# Altium schematic sheet Sensor_Box_IO_Relay.SchDoc
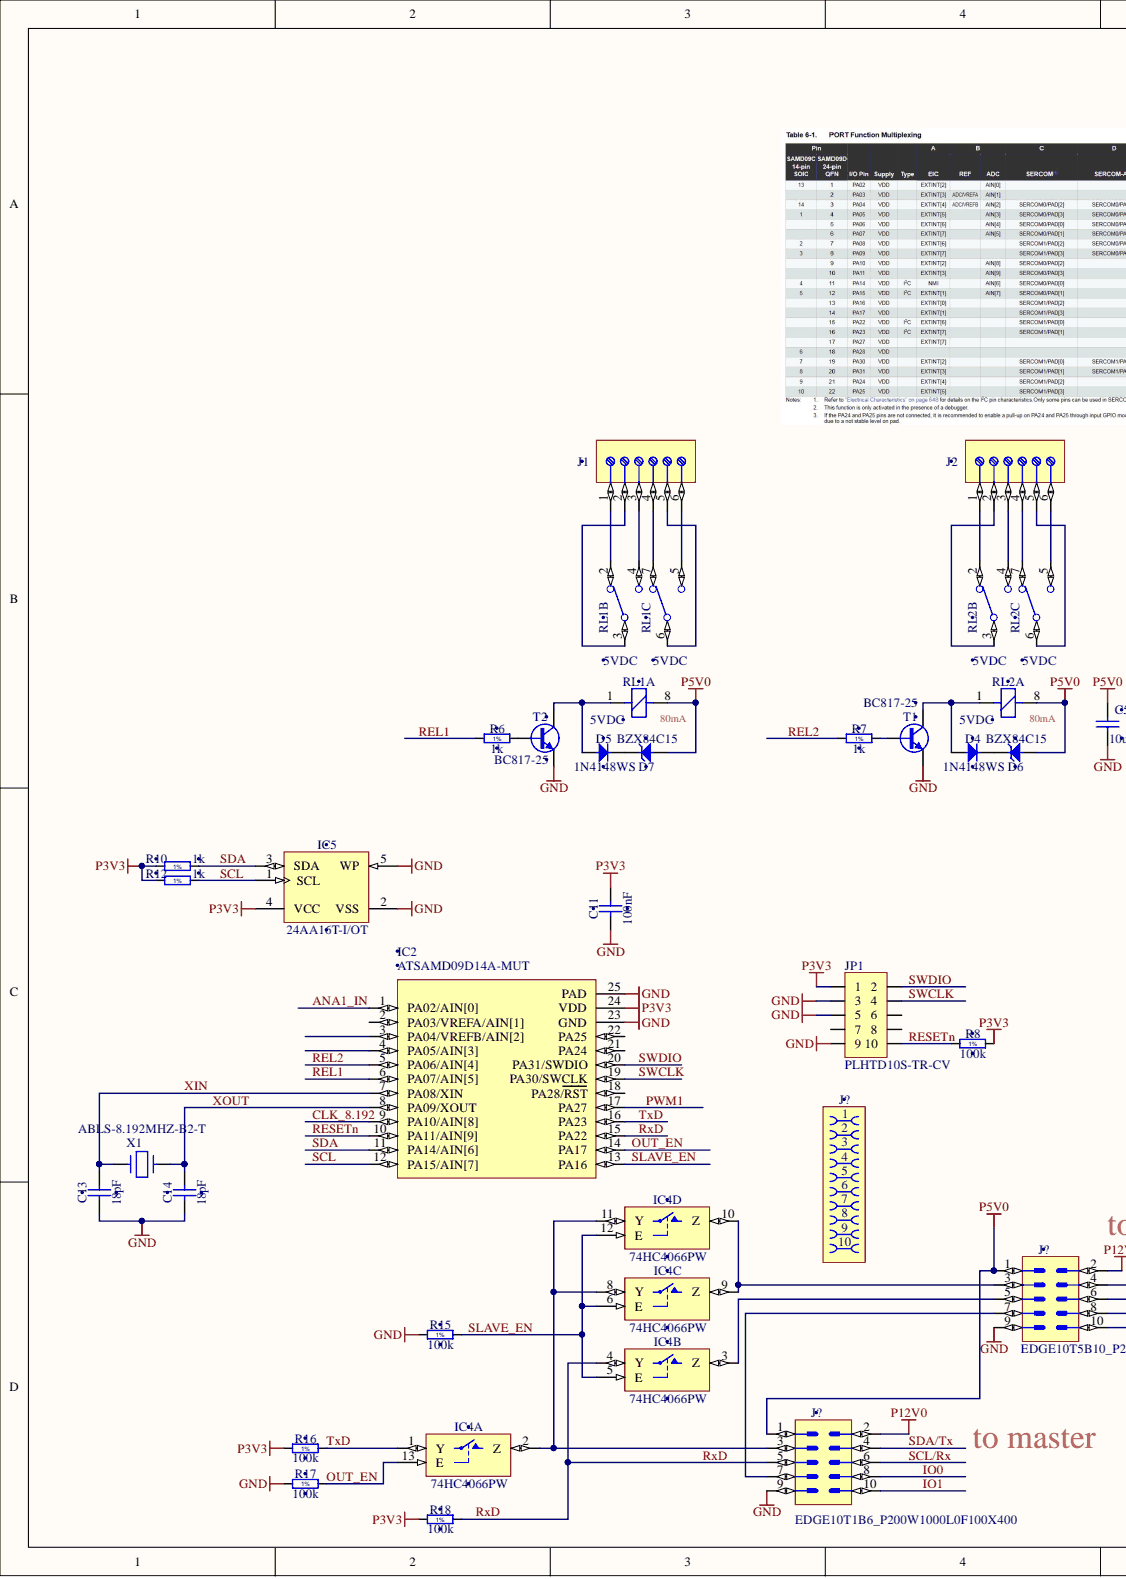
[diagram p0: Sensor_Box_IO_Relay.SchDoc, left]
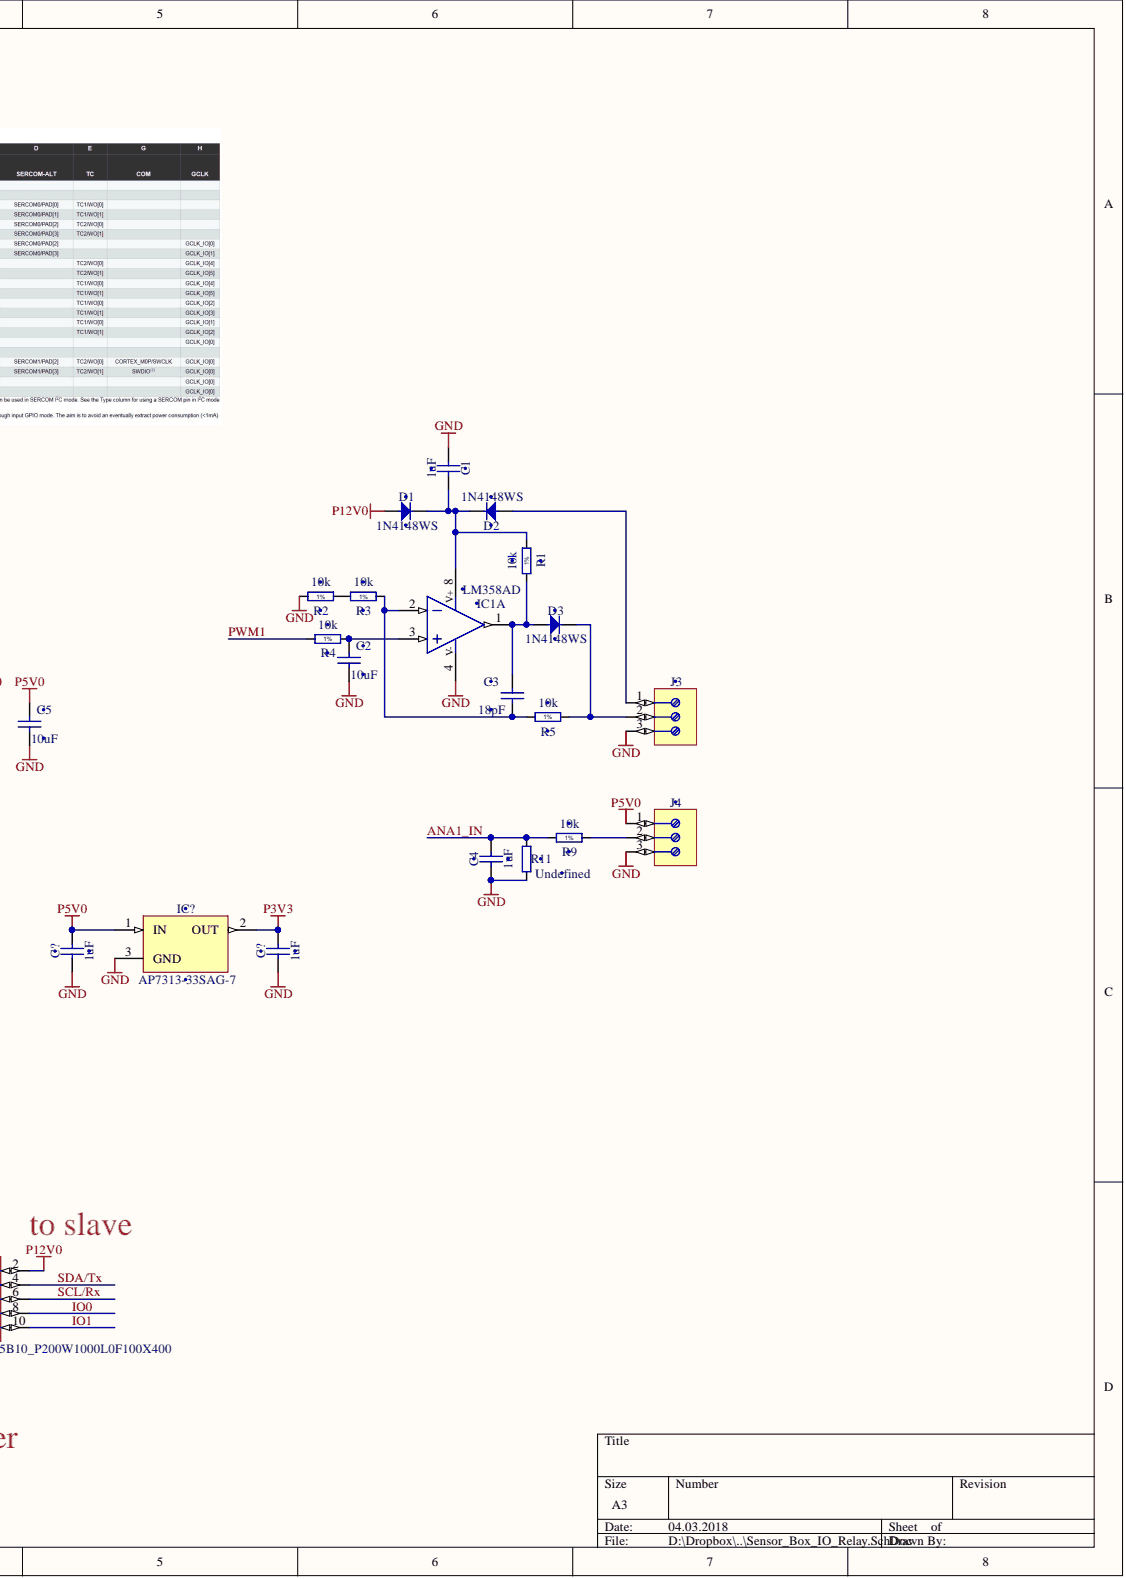
[diagram p1: Sensor_Box_IO_Relay.SchDoc, right]

## Parts
C1  1uF  [CAPC1005X55N]
C2  10uF  [CAPC2012X135N]
C3  18pF  [CAPC1005X55N]
C4  1uF  [CAPC1005X55N]
C5  10uF  [CAPC2012X135N]
C11  100nF  [CAPC1005X55N]
C13  18pF  [CAPC1005X55N]
C14  18pF  [CAPC1005X55N]
C?  1uF  [CAPC1608X87N]
C?  1uF  [CAPC1608X87N]
D1  1N4148WS  [SODFL2512X100N]
D2  1N4148WS  [SODFL2512X100N]
D3  1N4148WS  [SODFL2512X100N]
D4  1N4148WS  [SODFL2512X100N]
D5  1N4148WS  [SODFL2512X100N]
D6  BZX84C15  [SOT95P240X130-3N]
D7  BZX84C15  [SOT95P240X130-3N]
IC1  LM358AD  [SOIC127P600X175-8N]  (part A)
IC2  ATSAMD09D14A-MUT  [QFN50P400X400X80_HS-25N]
IC4  74HC4066PW  [SOP65P640X110-14N]  (parts A,B,C,D)
IC5  24AA16T-I/OT  [SOT95P280X145-5N]
IC?  AP7313-33SAG-7  [SOT95P285X140-3N]
J1  Family  [PHOENIX_1751138]  (value not drawn)
J2  Family  [PHOENIX_1751138]  (value not drawn)
J3  Family  [PHOENIX_1725669]  (value not drawn)
J4  Family  [PHOENIX_1725669]  (value not drawn)
J?  Family  [EDGE10T5B10_P200W1000L0F100X400]  (value not drawn)  (no BOM)
J?  Family  [EDGE10T1B6_P200W1000L0F100X400]  (value not drawn)  (no BOM)
J?
JP1  PLHTD10S-TR-CV  [PLHTD10S-TR-CV]
R1  10k  [RESC1005X40N]
R2  10k  [RESC1005X40N]
R3  10k  [RESC1005X40N]
R4  10k  [RESC1005X40N]
R5  10k  [RESC1005X40N]
R6  1k  [RESC1005X40N]
R7  1k  [RESC1005X40N]
R8  100k  [RESC1005X40N]
R9  10k  [RESC1005X40N]
R10  1k  [RESC1005X40N]
R11  Undefined  [RESC2012X60N]
R12  1k  [RESC1005X40N]
R15  100k  [RESC1005X40N]
R16  100k  [RESC1005X40N]
R17  100k  [RESC1005X40N]
R18  100k  [RESC1005X40N]
RL1  5VDC  [REL_OMRON_G2RL-2-5DC]  (parts A,B,C)
RL2  5VDC  [REL_OMRON_G2RL-2-5DC]  (parts A,B,C)
T1  BC817-25  [SOT95P230X110-3N]
T2  BC817-25  [SOT95P230X110-3N]
X1  ABLS-8.192MHZ-B2-T  [HC-49SM]

## Nets
GND: C1.1 C2.2 C4.1 C5.2 C11.1 C13.1 C14.1 C?.1 IC1.4 IC2.23(GND) IC2.25(PAD) IC4.7 IC5.2(VSS) IC5.5(WP) IC?.3 J3.3 J4.3 J?.9 JP1.3 JP1.5 JP1.9 R2.2 R11.1 R15.1 R17.1 T1.2 T2.2
P3V3: C11.2 C?.2 IC2.24(VDD) IC4.14 IC5.4(VCC) IC?.2 JP1.1 R8.2 R10.2 R12.2 R16.1 R18.1
P5V0: C5.1 C?.2 D6.1 D7.1 IC?.1 J4.1 J?.1 RL1.8 RL2.8
P12V0: D1.2 J?.2
ANA1_IN: C4.2 IC2.1(PA02/AIN[0]) R9.2 R11.2
CLK_8.192: IC2.9(PA10/AIN[8])
IO0: J?.8
IO1: J?.10
OUT_EN: IC2.14(PA17) IC4.13(E) R17.2
PWM1: IC2.17(PA27) R4.2
REL1: IC2.6(PA07/AIN[5]) R6.1
REL2: IC2.5(PA06/AIN[4]) R7.1
RESETn: IC2.10(PA11/AIN[9]) JP1.10 R8.1
RxD: IC2.15(PA22) IC4.4(Y) J?.5 R18.2
SCL: IC2.12(PA15/AIN[7]) IC5.1(SCL) R12.1
SCL/Rx: J?.6
SDA: IC2.11(PA14/AIN[6]) IC5.3(SDA) R10.1
SDA/Tx: J?.4
SLAVE_EN: IC2.13(PA16) IC4.5(E) IC4.6(E) IC4.12(E) R15.2
SWCLK: IC2.19(PA30/SWCLK) JP1.4
SWDIO: IC2.20(PA31/SWDIO) JP1.2
TxD: IC2.16(PA23) IC4.1(Y) R16.2
XIN: C13.2 IC2.7(PA08/XIN) X1.1
XOUT: C14.2 IC2.8(PA09/XOUT) X1.2
NetC1_2: C1.2 D1.1 D2.1 IC1.8 R1.1
NetC2_1: C2.1 IC1.3 R4.1
NetC3_1: C3.1 D3.2 IC1.1 R1.2
NetC3_2: C3.2 IC1.2 R3.1 R5.2
NetD2_2: D2.2 J3.1
NetD3_1: D3.1 J3.2 R5.1
NetD4_1: D4.1 D6.3
NetD4_2: D4.2 RL2.1 T1.3
NetD5_1: D5.1 D7.3
NetD5_2: D5.2 RL1.1 T2.3
NetIC4_2: IC4.2(Z) IC4.8(Y) IC4.11(Y) J?.3
NetIC4_3: IC4.3(Z) J?.5
NetIC4_9: IC4.9(Z) IC4.10(Z) J?.3
NetJ1_1: J1.1 RL1.2
NetJ1_2: J1.2 RL1.3
NetJ1_3: J1.3 RL1.4
NetJ1_4: J1.4 RL1.7
NetJ1_5: J1.5 RL1.6
NetJ1_6: J1.6 RL1.5
NetJ2_1: J2.1 RL2.2
NetJ2_2: J2.2 RL2.3
NetJ2_3: J2.3 RL2.4
NetJ2_4: J2.4 RL2.7
NetJ2_5: J2.5 RL2.6
NetJ2_6: J2.6 RL2.5
NetJ4_2: J4.2 R9.1
NetR2_1: R2.1 R3.2
NetR6_2: R6.2 T2.1
NetR7_2: R7.2 T1.1

Not connected: IC2 2(PA03/VREFA/AIN[1]) 3(PA04/VREFB/AIN[2]) 4(PA05/AIN[3]) 18(PA28/RST) 21(PA24) 22(PA25); J? 7; JP1 6 7 8

## Library data of the parts
C1 C4 = CC0402_1UF_16V_10%_X5R: Non-polarised capacitor; Manufacturer: GENERIC; Manufacturer1 Part Number: C1005X5R1C105KT; Part Description: SMD Multilayer Chip Ceramic Capacitor; Database Table Name: Capacitors SMD; TC: X5R; Tolerance: ±10%; Voltage: 16V; Case: 0402; Component Kind: Standard; Component Type: Standard; CreateDate: 25.10.2012; HelpURL: \\cern.ch\dfs\Applications\Altium\Datasheets\CC0402_X5R_TDK_C.pdf; LatestRevisionDate: 25.10.2012; Library Name: Capacitors.DbLib; Manufacturer1 ComponentHeight: 0.55mm; Manufacturer1 Example: TDK; Manufacturer Part Number: CC0402_1UF_16V_10%_X5R; Mounted: Yes; Part Number: CC0402_1UF_16V_10%_X5R; Pin Count: 2; Sense: No; SMD: Yes; Socket: No; Status: Preferred; Value: 1uF; Author: CERN DEM JLC
C2 C5 = CC0805_10UF_10V_10%_X7R: Non-polarised capacitor; Manufacturer: GENERIC; Manufacturer1 Part Number: GRM21BR71A106KE51L; Part Description: SMD Multilayer Chip Ceramic Capacitor; Database Table Name: Capacitors SMD; TC: X7R; Tolerance: ±10%; Voltage: 10V; Case: 0805; Component Kind: Standard; Component Type: Standard; CreateDate: 2012-04-30; HelpURL: \\cern.ch\dfs\Applications\Altium\Datasheets\CC0805_X7R_MURATA.pdf; LatestRevisionDate: 2012-04-30; Library Name: Capacitors.DbLib; Manufacturer1 ComponentHeight: 1.35mm; Manufacturer1 Example: MURATA; Manufacturer Part Number: CC0805_10UF_10V_10%_X7R; Mounted: Yes; Part Number: CC0805_10UF_10V_10%_X7R; Pin Count: 2; SMD: Yes; Socket: No; Status: Preferred; Table: Capacitors SMD; Value: 10uF; Author: CERN DEM JLC
C3 C13 C14 = CC0402_18PF_50V_5%_C0G: Non-polarised capacitor; Manufacturer: GENERIC; Manufacturer1 Part Number: C0402C180J5GACTU; Part Description: SMD Multilayer Chip Ceramic Capacitor; Database Table Name: Capacitors SMD; TC: C0G/NP0; Tolerance: ±5%; Voltage: 50V; Case: 0402; Component Kind: Standard; Component Type: Standard; CreateDate: 04.10.2016; HelpURL: \\cern.ch\dfs\Applications\Altium\Datasheets\CC0805_C0G_KEMET_(Commercial Grade).pdf; LatestRevisionDate: 04.10.2016; Library Name: Capacitors.DbLib; Manufacturer1 ComponentHeight: 0.55mm; Manufacturer1 Example: KEMET; Manufacturer Part Number: CC0402_18PF_50V_5%_C0G; Mounted: Yes; Part Number: CC0402_18PF_50V_5%_C0G; Pin Count: 2; Sense: No; SMD: Yes; Socket: No; Status: Preferred; Value: 18pF; Author: CERN DEM JLC
C11 = CC0402_100NF_16V_10%_X5R: Non-polarised capacitor; Manufacturer: GENERIC; Manufacturer1 Part Number: GRM155R61C104KA88D; Part Description: SMD Multilayer Chip Ceramic Capacitor; Database Table Name: Capacitors SMD; TC: X5R; Tolerance: ±10%; Voltage: 16V; Case: 0402; Component Kind: Standard; Component Type: Standard; CreateDate: 10.12.2013; HelpURL: \\cern.ch\dfs\Applications\Altium\Datasheets\CC0402_X5R_MURATA_GRM.pdf; LatestRevisionDate: 10.12.2013; Library Name: Capacitors.DbLib; Manufacturer1 ComponentHeight: 0.55mm; Manufacturer1 Example: MURATA; Manufacturer Part Number: CC0402_100NF_16V_10%_X5R; Mounted: Yes; Part Number: CC0402_100NF_16V_10%_X5R; Pin Count: 2; Sense: No; SMD: Yes; Socket: No; Status: None; Value: 100nF; Author: CERN DEM JLC
C? C? = CC0603_1UF_16V_10%_X7R: Non-polarised capacitor; Manufacturer: GENERIC; Manufacturer1 Part Number: 223878615663; Part Description: SMD Multilayer Chip Ceramic Capacitor; Database Table Name: Capacitors SMD; TC: X7R; Tolerance: ±10%; Voltage: 16V; Case: 0603; Component Kind: Standard; Component Type: Standard; CreateDate: 03.12.2007; HelpURL: \\cern.ch\dfs\Applications\Altium\Datasheets\CC0603_X7R_PHYCOMP.pdf; LatestRevisionDate: 17.04.2015; Library Name: Capacitors.DbLib; Manufacturer1 ComponentHeight: 0.9mm; Manufacturer1 Example: YAGEO PHYCOMP; Manufacturer Part Number: CC0603_1uF_16V_10%_X7R; Mounted: Yes; Part Number: CC0603_1UF_16V_10%_X7R; Pin Count: 2; Sense: No; SMD: Yes; Socket: No; Status: None; Value: 1uF; Author: CERN DEM JLC
D1-D5 = 1N4148WS: Diode; Manufacturer: FAIRCHILD SEMICONDUCTOR; Part Description: Small Signal Diodes; Bonding: No; Database Table Name: Diodes; Power: 150mA; PressFit: No; Voltage: 75V; Case: SOD-323F; ComponentHeight: 1mm; Component Kind: Standard; Component Type: Standard; CreateDate: 12.05.2011; Device: 1N4148WS; HelpURL: \\cern.ch\dfs\Applications\Altium\Datasheets\1N4148WS.pdf; LatestRevisionDate: 12.05.2011; Library Name: ICs And Semiconductors.DbLib; Manufacturer Part Number: 1N4148WS; Mounted: Yes; PackageDescription: SODFL Diode; 1.70mm W X 1.25mm L X 1.00mm H body, IPC Medium Density; Part Number: 1N4148WS; Pin Count: 2; Sense: No; SMD: Yes; Socket: No; Author: CERN DEM JLC
D6 D7 = BZX84C15: Diode Zener Anode Pin 1 Cathode Pin 3 (Pas de pin 2); Manufacturer: FAIRCHILD SEMICONDUCTOR; Part Description: Zener Diode; Bonding: No; Database Table Name: Diodes; Power: 350mW; PressFit: No; Voltage: 15V; Case: SOT23-3; ComponentHeight: 1.3mm; Component Kind: Standard; Component Type: Standard; CreateDate: 30.11.2011; Device: BZX84C15; HelpURL: \\cern.ch\dfs\Applications\Altium\Datasheets\BZX84C15.pdf; LatestRevisionDate: 30.11.2011; Library Name: ICs And Semiconductors.DbLib; Manufacturer Part Number: BZX84C15; Mounted: Yes; PackageDescription: SOT23 3-Leads, Pitch 0.95mm - Body 1.3x2.9mm, IPC Medium Density; Part Number: BZX84C15; Pin Count: 3; Sense: No; SMD: Yes; Socket: No; Author: CERN DEM JLC
IC1 = LM358AD: Dual Operational Amplifier; Manufacturer: TEXAS INSTRUMENTS; Bonding: No; Database Table Name: Operational Amplifiers; PressFit: No; Case: SOIC8; ComponentHeight: 1.75mm; Component Kind: Standard; Component Type: Standard; CreateDate: 02.12.2008; Device: LM358AD; HelpURL: \\cern.ch\dfs\Applications\Altium\Datasheets\LM358AD.pdf; LatestRevisionDate: 13.04.2010; Library Name: ICs And Semiconductors.DbLib; Manufacturer Part Number: LM358AD; Mounted: Yes; PackageDescription: SOIC 8, Pitch 1.27mm - Body 4x5mm, IPC Medium Density; Part Description: Dual Operational Amplifier; Part Number: LM358AD; Pin Count: 8; Sense: No; SMD: Yes; Socket: No; Author: CERN DEM JLC
IC2 = (no symbol name): 32-bit ARM®-based Cortex®-M4 core Microcontroller, 1.62V to 3.6V, 120MHz, 256KB Flash, 64KB of SRAM, 48 QFP, Industrial Grade (-40°C to 85°C); Manufacturer: Microchip; Part Description: ATSAMD09D14A-MUT  SMART SAMD09, SMART ARM based Microcontrollers, 32 bity, 48 MHz, 16 KB, 4 KB; Bonding: No; PressFit: No; Case: QFN24; ComponentHeight: 1.6mm; Component Kind: Standard; Component Type: Standard; CreateDate: 16.12.2017; Device: ATSAMD09D14A-MUT; HelpURL: \\cern.ch\dfs\Applications\Altium\Datasheets\ATSAM4S4AA-AU.pdf; LatestRevisionDate: 16.12.2017; Library Name: LIBRARY_SCH.SCHLIB; Manufacturer Part Number: ATSAMD09D14A-MUT; Mounted: Yes; PackageDescription: QFN, 24-Leads, Body 4.00x4.00mm, Pitch 0.50mm, Thermal Pad 2.60x2.60mm, IPC Medium Density; Part Number: ATSAMD09D14A-MUT; Pin Count: 24; Sense: No; SMD: Yes; Socket: No; Author: GK
IC4 = 74HC4066PW: Manufacturer: NXP SEMICONDUCTORS; Part Description: Quad Single-Pole Single-Throw Analog Switch; Bonding: No; Database Table Name: Standard Logic; PressFit: No; Case: TSSOP14; ComponentHeight: 1.1mm; Component Kind: Standard; Component Type: Standard; CreateDate: 19.11.2014; Device: 74HC4066PW; HelpURL: \\cern.ch\dfs\Applications\Altium\Datasheets\74HC4066PW.pdf; LatestRevisionDate: 19.11.2014; Library Name: ICs And Semiconductors.DbLib; Manufacturer Part Number: 74HC4066PW; Mounted: Yes; PackageDescription: SOP, 14-Leads, Body 4.4x5mm (max), Pitch 0.65mm, IPC Medium Density; Part Number: 74HC4066PW; Pin Count: 14; Sense: No; SMD: Yes; Socket: No; Author: CERN DEM JLC
IC5 = (no symbol name): 1.7V-5.5V, 2K I2C Serial EEPROM With EUI-48 Node Identity; Manufacturer: MICROCHIP TECHNOLOGY; Part Description: 1.7V-5.5V, 16K I2C Serial EEPROM; Bonding: No; PressFit: No; Case: SOT23-5; ComponentHeight: 1.45mm; Component Kind: Standard; Component Type: Standard; CreateDate: 31.03.2016; Device: 24AA16T-I/OT; HelpURL: \\cern.ch\dfs\Applications\Altium\Datasheets\24AA02E48T-I-OT.pdf; LatestRevisionDate: 31.03.2016; Library Name: LIBRARY_SCH.SCHLIB; Manufacturer Part Number: 24AA16T-I/OT; Mounted: Yes; PackageDescription: SOT, 0.95mm pitch; 5 pin, 1.60mm W X 2.90mm L X 1.45mm H body, IPC Medium Density; Part Number: 24AA16T-I/OT; Pin Count: 5; Sense: No; SMD: Yes; Socket: No; Author: GK
IC? = (no symbol name): 150mA, Low Quiescent Current, Fast Transient Low Dropout Linear Regulator; Manufacturer: DIODES INCORPORATED; Bonding: No; PressFit: No; Case: SOT23; ComponentHeight: 1.45mm; Component Kind: Standard; Component Type: Standard; CreateDate: 10.07.2015; Device: AP7313-33SAG-7; HelpURL: \\cern.ch\dfs\Applications\Altium\Datasheets\AP133-WG-7.pdf; LatestRevisionDate: 10.07.2015; Library Name: LIBRARY_SCH.SCHLIB; Manufacturer Part Number: AP7313-33SAG-7; Mounted: Yes; PackageDescription: SOT23, 0.95mm pitch; 3 pin, 1.6mm W X 3.0mm L X 1.45mm H body, IPC Medium Density; Part Description: 150mA, Low Quiescent Current, Fast Transient Low Dropout Linear Regulator; Part Number: AP7313-33SAG-7; Pin Count: 5; Sense: No; SMD: Yes; Socket: No; Author: GK
J1 J2 = PHOENIX_1751138: Terminal Block, 6 Positions, Screw Connection; Manufacturer: PHOENIX CONTACT; Manufacturer Part Number: 1751138 (SMKDS 1/ 6-3,5); Part Description: 10A 200V PCB Terminal Block, Pitch 3.5mm, 6 Positions, Screw Connection (Conductor/PCB connection direction: 55°); PressFit: No; ComponentHeight: 9.5mm; Component Kind: Standard; Component Type: Standard; CreateDate: 31.03.2015; Database Table Name: PHOENIX; HelpURL: \\cern.ch\dfs\Applications\Altium\Datasheets\PHOENIX_1751138.pdf; LatestRevisionDate: 31.03.2015; Library Name: Connectors.DbLib; Mounted: Yes; Part Number: PHOENIX_1751138; Pin Count: 6; Sense: No; SMD: No; Socket: No; Status: None; Author: CERN DEM JLC
J3 J4 = PHOENIX_1725669: Terminal Block, 3 Positions, Screw Connection; Manufacturer: PHOENIX CONTACT; Manufacturer Part Number: 1725669 (MPT 0,5/3-2,54); Part Description: 6A, 63V, Terminal Block, Pitch 2.54mm, 3 Positions, Screw Connection; PressFit: No; ComponentHeight: 8.5mm; Component Kind: Standard; Component Type: Standard; CreateDate: 07.11.2008; Database Table Name: PHOENIX; HelpURL: \\cern.ch\dfs\Applications\Altium\Datasheets\PHOENIX_1725669.pdf; LatestRevisionDate: 26.04.2010; Library Name: Connectors.DbLib; Mounted: Yes; Part Number: PHOENIX_1725669; Pin Count: 3; Sense: No; SMD: No; Socket: No; Status: None; Author: CERN DEM JMW
J? = EDGE10T5B10_P200W1000L0F100X400: PCB Edge Connector 10 (2x5); Part Description: 10 Contacts Board Edge Connector, Pitch 2mm, Length 0mm, Width 10mm, Finger Lenght 4mm, Finger Width 1mm; Database Table Name: Miscellaneous; Mounted: No; PressFit: No; ComponentHeight: 0mm; Component Type: Standard (No BOM); CreateDate: 14.02.2018; HelpURL: \\cern.ch\dfs\Applications\Altium\Datasheets\EDGE10T5B10_P200W1000L0F100X400.pdf; LatestRevisionDate: 14.02.2018; Library Name: Connectors.DbLib; Part Number: EDGE10T5B10_P200W1000L0F100X400; Pin Count: 10; Sense: No; SMD: No; Socket: No; Status: None; Author: CERN DEM MR
J? = EDGE10T1B6_P200W1000L0F100X400: PCB Edge Connector 10 (2x5); Part Description: 10 Contacts Board Edge Connector, Pitch 2mm, Length 0mm, Width 10mm, Finger Lenght 4mm, Finger Width 1mm; Database Table Name: Miscellaneous; Mounted: No; PressFit: No; ComponentHeight: 0mm; Component Type: Standard (No BOM); CreateDate: 14.02.2018; HelpURL: \\cern.ch\dfs\Applications\Altium\Datasheets\EDGE10T1B6_P200W1000L0F100X400.pdf; LatestRevisionDate: 14.02.2018; Library Name: Connectors.DbLib; Part Number: EDGE10T1B6_P200W1000L0F100X400; Pin Count: 10; Sense: No; SMD: No; Socket: No; Status: None; Author: CERN DEM MR
JP1 = CON_2x5 1.27 SMT Male: 1.27 mm dual row goldpin (Maritex); Component Kind: Standard; Library Name: LIBRARY_SCH.SCHLIB
R1-R5 R9 = R0402_10K_1%_0.0625W_100PPM: Resistor - 1%; Manufacturer: GENERIC; Manufacturer1 Part Number: 232270671003L; Part Description: General Purpose Thick Film Chip Resistor; Database Table Name: Resistors SMD; TC: ±100ppm/°C; Case: 0402; Component Kind: Standard; Component Type: Standard; CreateDate: 2007-12-03; HelpURL: \\cern.ch\dfs\Applications\Altium\Datasheets\R0402_Phycomp_RC0402.pdf; LatestRevisionDate: 2012-10-17; Library Name: Resistors.DbLib; Manufacturer1 ComponentHeight: 0.4mm; Manufacturer1 Example: YAGEO PHYCOMP; Manufacturer Part Number: R0402_10K_1%_0.0625W_100PPM; Mounted: Yes; Part Number: R0402_10K_1%_0.0625W_100PPM; Pin Count: 2; Power: 0.0625W; SMD: Yes; Socket: No; Status: Not recommended; Table: Resistors SMD; Tolerance: ±1%; Value: 10k; Author: CERN DEM JLC
R6 R7 R10 R12 = R0402_1K_1%_0.0625W_100PPM: Resistor - 1%; Manufacturer: GENERIC; Manufacturer1 Part Number: 232270671002L; Part Description: General Purpose Thick Film Chip Resistor; Database Table Name: Resistors SMD; PressFit: No; TC: ±100ppm/°C; Case: 0402; Component Kind: Standard; Component Type: Standard; CreateDate: 03.12.2007; HelpURL: \\cern.ch\dfs\Applications\Altium\Datasheets\R0402_Phycomp_RC0402.pdf; LatestRevisionDate: 17.10.2012; Library Name: Resistors.DbLib; Manufacturer1 ComponentHeight: 0.4mm; Manufacturer1 Example: YAGEO PHYCOMP; Manufacturer Part Number: R0402_1K_1%_0.0625W_100PPM; Mounted: Yes; Part Number: R0402_1K_1%_0.0625W_100PPM; Pin Count: 2; Power: 0.0625W; Sense: No; SMD: Yes; Socket: No; Status: Not Recommended; Tolerance: ±1%; Value: 1k; Author: CERN DEM JLC
R8 R15-R18 = R0402_100K_1%_0.0625W_100PPM: Resistor - 1%; Manufacturer: GENERIC; Manufacturer1 Part Number: 232270671004L; Part Description: General Purpose Thick Film Chip Resistor; Database Table Name: Resistors SMD; PressFit: No; TC: ±100ppm/°C; Case: 0402; Component Kind: Standard; Component Type: Standard; CreateDate: 03.12.2007; HelpURL: \\cern.ch\dfs\Applications\Altium\Datasheets\R0402_Phycomp_RC0402.pdf; LatestRevisionDate: 17.10.2012; Library Name: Resistors.DbLib; Manufacturer1 ComponentHeight: 0.4mm; Manufacturer1 Example: YAGEO PHYCOMP; Manufacturer Part Number: R0402_100K_1%_0.0625W_100PPM; Mounted: Yes; Part Number: R0402_100K_1%_0.0625W_100PPM; Pin Count: 2; Power: 0.0625W; Sense: No; SMD: Yes; Socket: No; Status: Not Recommended; Tolerance: ±1%; Value: 100k; Author: CERN DEM JLC
R11 = R0805_NO-VALUE: Resistor; Part Description: Reserved Footprint For a 0805 (2012) Chip Resistor; Database Table Name: Resistors SMD; Mounted: No; PressFit: No; Case: 0805; Component Kind: Standard; Component Type: Standard; CreateDate: 03.12.2007; HelpURL: Undefined; LatestRevisionDate: 13.03.2008; Library Name: Resistors.DbLib; Manufacturer: Undefined; Manufacturer1 ComponentHeight: Undefined; Manufacturer1 Example: Undefined; Manufacturer1 Part Number: Undefined; Manufacturer Part Number: R0805_NO-VALUE; Part Number: R0805_NO-VALUE; Pin Count: 2; Sense: No; SMD: Yes; Socket: No; Status: None; Value: Undefined; Author: CERN DEM JLC
RL1 RL2 = (no symbol name): DPDT Power Relay, G2RL Series; Manufacturer: OMRON ELECTRONIC; Manufacturer Part Number: G2RL-2-5DC; PressFit: No; ComponentHeight: 15.7mm; Component Kind: Standard; Component Type: Standard; CreateDate: 16.06.2015; HelpURL: \\cern.ch\dfs\Applications\Altium\Datasheets\REL_OMRON_G2RL-24.pdf; LatestRevisionDate: 16.06.2015; Library Name: LIBRARY_SCH.SCHLIB; Mounted: Yes; PackageDescription: 8 Leads, Row Spacing 7.5mm; Body 29mm x 12.7mm, OMRON_G2RL Series; Part Description: DPDT Power Relay, G2RL Series; Part Number: REL_OMRON_G2RL-2-5DC; Pin Count: 8; Sense: No; SMD: No; Socket: No; Status: None; Value: 5VDC; Author: GK
T1 T2 = BC817-25: NPN Transistor; Manufacturer: NXP SEMICONDUCTORS; Part Description: 45V 500mA NPN General-Purpose Transistor; Bonding: No; Database Table Name: Transistors; PressFit: No; Case: SOT23-3; ComponentHeight: 1.1mm; Component Kind: Standard; Component Type: Standard; CreateDate: 28.10.2014; Device: BC817-25; HelpURL: \\cern.ch\dfs\Applications\Altium\Datasheets\BC817-25.pdf; LatestRevisionDate: 28.10.2014; Library Name: ICs And Semiconductors.DbLib; Manufacturer Part Number: BC817-25; Mounted: Yes; PackageDescription: SOT23, 3 pin, Pitch 0.95mm - 1.3mm W X 2.9mm L X 1.1mm H, IPC Medium Density; Part Number: BC817-25; Pin Count: 3; Sense: No; SMD: Yes; Socket: No; Author: CERN DEM JLC
X1 = X_CRYSTAL_HC49_SMT: Crystal oscillator; Component Kind: Standard; Library Name: LIBRARY_SCH.SCHLIB; Supplier 1: Newark; Supplier Part Number 1: 56P2843
